# Altium schematic sheet ESP32.SchDoc(ESP32)
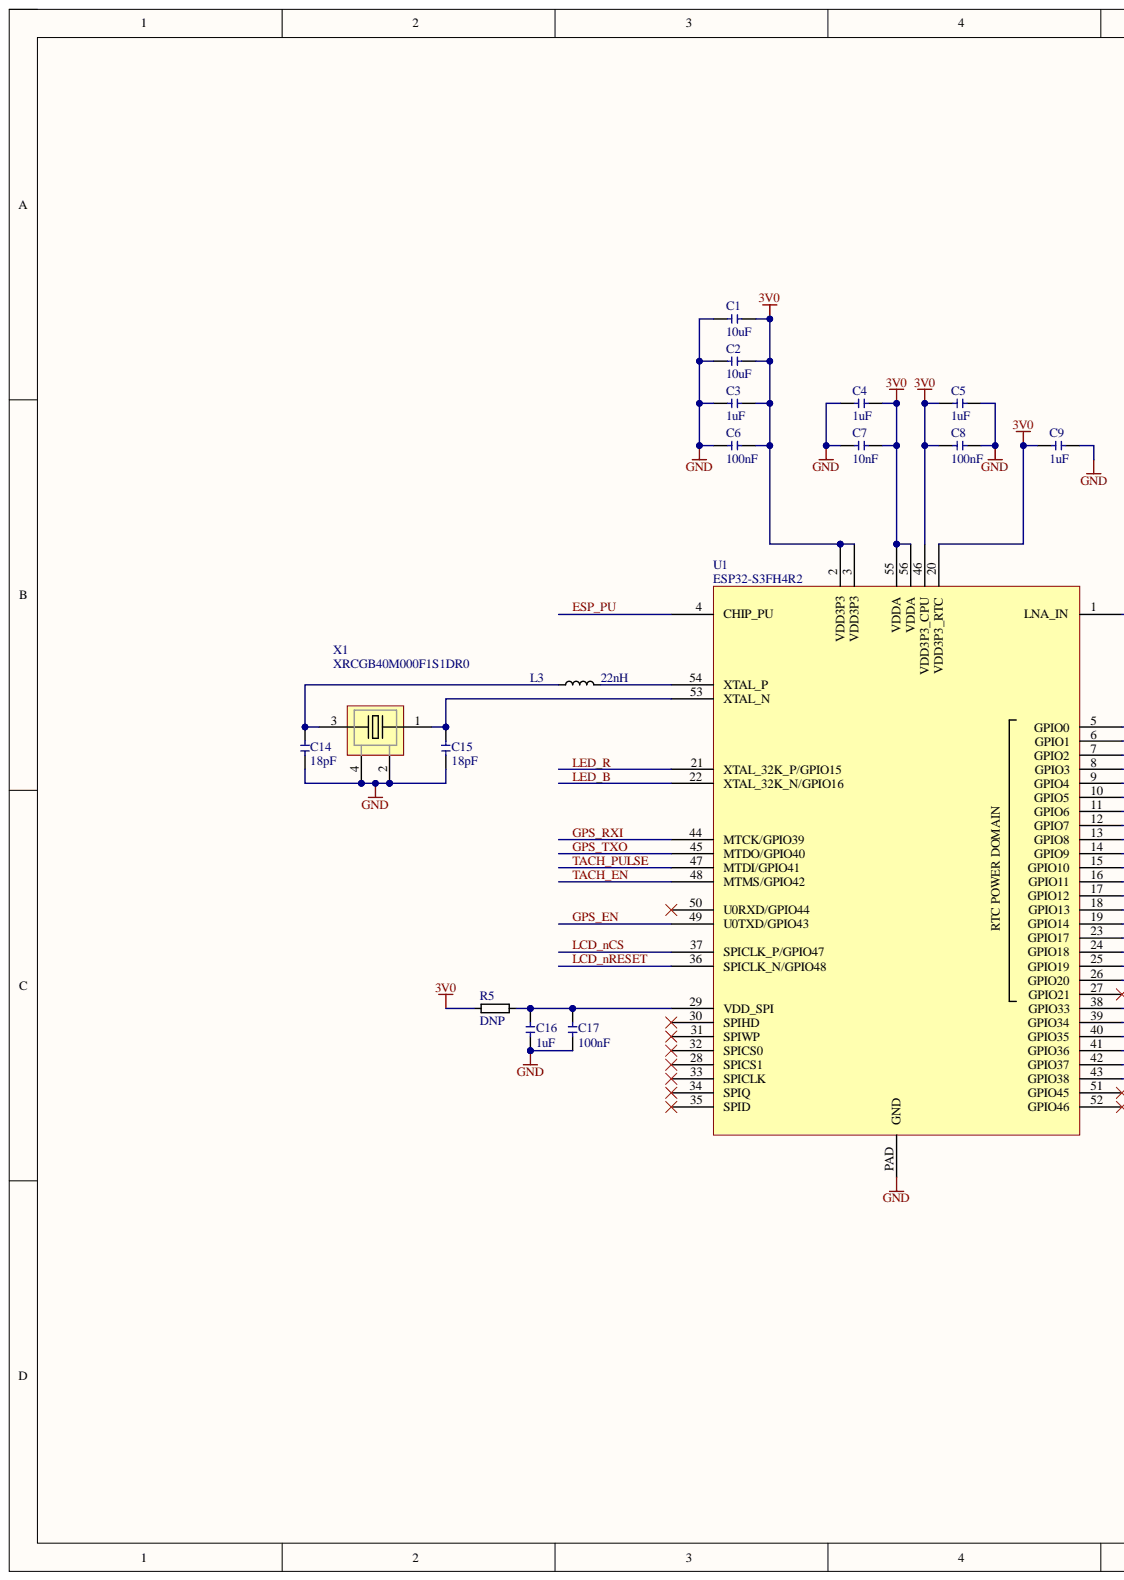
[diagram p0: ESP32.SchDoc, left]
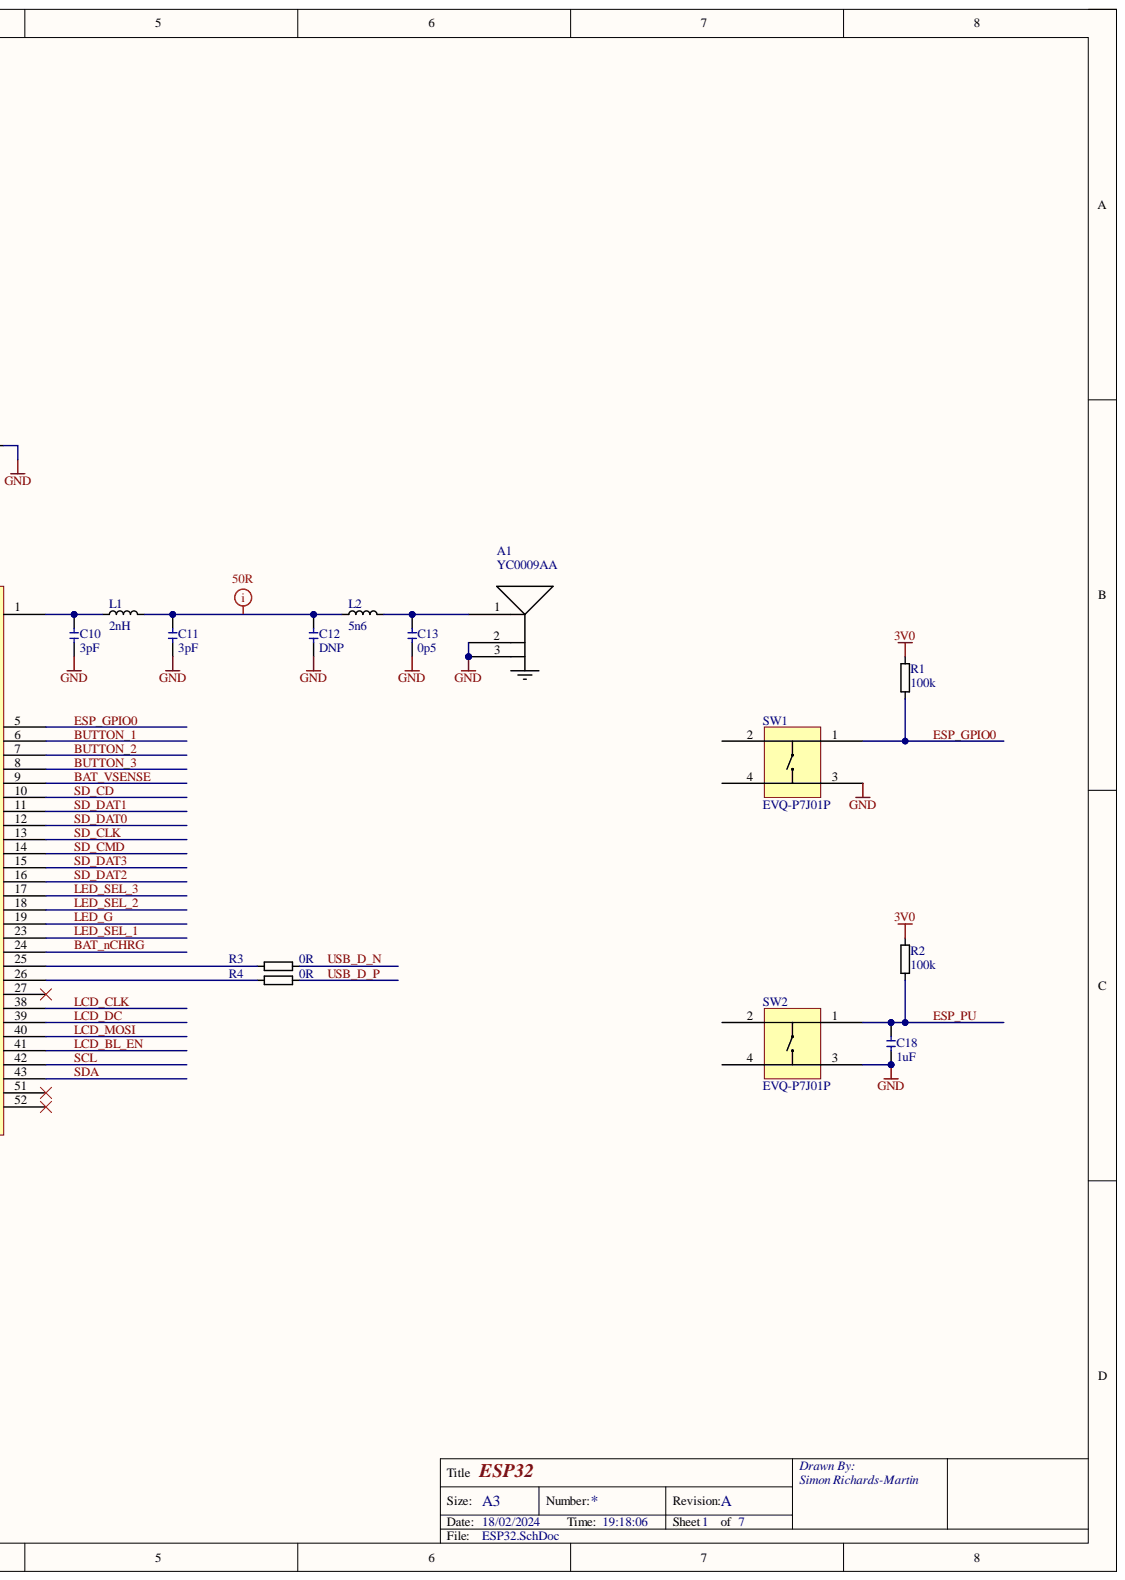
[diagram p1: ESP32.SchDoc, right]

## Parts
A1  YC0009AA  [YC0009AA]
C1  10uF  [CHIP-0402]
C2  10uF  [CHIP-0402]
C3  1uF  [CHIP-0402]
C4  1uF  [CHIP-0402]
C5  1uF  [CHIP-0402]
C6  100nF  [CHIP-0402]
C7  10nF  [CHIP-0402]
C8  100nF  [CHIP-0402]
C9  1uF  [CHIP-0402]
C10  3pF  [CHIP-0402]
C11  3pF  [CHIP-0402]
C12  DNP  [CHIP-0402]
C13  0p5  [CHIP-0402]
C14  18pF  [CHIP-0402]
C15  18pF  [CHIP-0402]
C16  1uF  [CHIP-0402]
C17  100nF  [CHIP-0402]
C18  1uF  [CHIP-0402]
L1  2nH  [CHIP-0402]
L2  5n6  [CHIP-0402]
L3  22nH  [CHIP-0402]
R1  100k  [CHIP-0402]
R2  100k  [CHIP-0402]
R3  0R  [CHIP-0402]
R4  0R  [CHIP-0402]
R5  DNP  [CHIP-0402]
SW1  EVQ-P7J01P  [EVQ-P7J01P]
SW2  EVQ-P7J01P  [EVQ-P7J01P]
U1  ESP32-S3FH4R2  [QFN40P700X700X90-57N]
X1  XRCGB40M000F1S1DR0  [CRYSTAL-1.6X2.0]

## Nets
3V0: C1.2 C2.2 C3.2 C4.2 C5.1 C6.2 C7.2 C8.1 C9.1 R1.2 R2.2 R5.1 U1.2(VDD3P3) U1.3(VDD3P3) U1.20(VDD3P3_RTC) U1.46(VDD3P3_CPU) U1.55(VDDA) U1.56(VDDA)
GND: A1.2 A1.3 C1.1 C2.1 C3.1 C4.1 C5.2 C6.1 C7.1 C8.2 C9.2 C10.2 C11.2 C12.2 C13.2 C14.2 C15.2 C16.2 C17.2 C18.2 SW1.3 SW2.3 U1.PAD(GND) X1.2 X1.4
BAT_nCHRG: U1.24(GPIO18)
BAT_VSENSE: U1.9(GPIO4)
BUTTON_1: U1.6(GPIO1)
BUTTON_2: U1.7(GPIO2)
BUTTON_3: U1.8(GPIO3)
ESP_GPIO0: R1.1 SW1.1 U1.5(GPIO0)
ESP_PU: C18.1 R2.1 SW2.1 U1.4(CHIP_PU)
GPS_EN: U1.49(U0TXD/GPIO43)
GPS_RXI: U1.44(MTCK/GPIO39)
GPS_TXO: U1.45(MTDO/GPIO40)
LCD_BL_EN: U1.41(GPIO36)
LCD_CLK: U1.38(GPIO33)
LCD_DC: U1.39(GPIO34)
LCD_MOSI: U1.40(GPIO35)
LCD_nCS: U1.37(SPICLK_P/GPIO47)
LCD_nRESET: U1.36(SPICLK_N/GPIO48)
LED_B: U1.22(XTAL_32K_N/GPIO16)
LED_G: U1.19(GPIO14)
LED_R: U1.21(XTAL_32K_P/GPIO15)
LED_SEL_1: U1.23(GPIO17)
LED_SEL_2: U1.18(GPIO13)
LED_SEL_3: U1.17(GPIO12)
SCL: U1.42(GPIO37)
SD_CD: U1.10(GPIO5)
SD_CLK: U1.13(GPIO8)
SD_CMD: U1.14(GPIO9)
SD_DAT0: U1.12(GPIO7)
SD_DAT1: U1.11(GPIO6)
SD_DAT2: U1.16(GPIO11)
SD_DAT3: U1.15(GPIO10)
SDA: U1.43(GPIO38)
TACH_EN: U1.48(MTMS/GPIO42)
TACH_PULSE: U1.47(MTDI/GPIO41)
USB_D_N: R3.2
USB_D_P: R4.2
NetA1_1: A1.1 C13.1 L2.1
NetC10_1: C10.1 L1.2 U1.1(LNA_IN)
NetC11_1: C11.1 C12.1 L1.1 L2.2
NetC14_1: C14.1 L3.2 X1.3
NetC15_1: C15.1 U1.53(XTAL_N) X1.1
NetC16_1: C16.1 C17.1 R5.2 U1.29(VDD_SPI)
NetL3_1: L3.1 U1.54(XTAL_P)
NetR3_1: R3.1 U1.25(GPIO19)
NetR4_1: R4.1 U1.26(GPIO20)

Not connected: SW1 2 4; SW2 2 4; U1 27(GPIO21) 28(SPICS1) 30(SPIHD) 31(SPIWP) 32(SPICS0) 33(SPICLK) 34(SPIQ) 35(SPID) 50(U0RXD/GPIO44) 51(GPIO45) 52(GPIO46)

## Library data of the parts
A1 = YC0009AA: Antenna; Manufacturer: Quectel; Component Kind: Standard; MPN: YC0009AA; Library Name: Paramotor Sensor Main.SchLib
C1 C2 = Capacitor 10uF 10V 0402 X5R: Manufacturer: Murata; MPN: GRM155R61A106ME11D; Component Kind: Standard; Description: Capacitor 10uF 10V 0402 X5R; Library Name: Paramotor Sensor Main.SchLib
C3-C5 C9 C16 C18 = Capacitor 1uF 50V 0402 X5R: Manufacturer: Murata; MPN: GRM155R61H105KE05D; Component Kind: Standard; Description: Capacitor 1uF 50V 0402 X5R; Library Name: Paramotor Sensor Main.SchLib
C6 C8 C17 = Capacitor 100nF 50V 0402 X5R: Manufacturer: Murata; MPN: GRM155R61H104KE14D; Component Kind: Standard; Description: Capacitor 100nF 50V 0402 X5R; Library Name: Paramotor Sensor Main.SchLib
C7 = Capacitor 10nF 25V 0402 C0G: Manufacturer: Murata; MPN: GRM1555C1E103GE01D; Component Kind: Standard; Description: Capacitor 10nF 25V 0402 C0G; Library Name: Paramotor Sensor Main.SchLib
C10 C11 = Capacitor RF 3pF 50V 0402 C0G: Manufacturer: Murata; MPN: GJM1555C1H3R0CB01D; Component Kind: Standard; Description: Capacitor RF 3pF 50V 0402 C0G; Library Name: Paramotor Sensor Main.SchLib
C12 = Capacitor DNP 0402: Manufacturer: DO NOT POPULATE; Component Kind: Standard; Description: Capacitor DNP 0402; MPN: DO NOT POPULATE; Library Name: Paramotor Sensor Main.SchLib
C13 = Capacitor RF 0.5pF 50V 0402 C0G: Manufacturer: Murata; MPN: GJM1555C1HR50BB01J; Component Kind: Standard; Description: Capacitor RF 0.5pF 50V 0402 C0G; Library Name: Paramotor Sensor Main.SchLib
C14 C15 = Capacitor 18pF 50V 0402 C0G: Manufacturer: Murata; MPN: GRT1555C1H180JA02D; Component Kind: Standard; Description: Capacitor 18pF 50V 0402 C0G; Library Name: Paramotor Sensor Main.SchLib
L1 = Inductor RF 2nH 90mR 0402: Manufacturer: Murata; MPN: LQG15HN2N0S02D; Component Kind: Standard; Description: Inductor RF 2nH 90mR 0402; Library Name: Paramotor Sensor Main.SchLib
L2 = Inductor RF 5.6nH 180mR 0402: Manufacturer: Murata; MPN: LQG15HN5N6B02D; Component Kind: Standard; Description: Inductor RF 5.6nH 180mR 0402; Library Name: Paramotor Sensor Main.SchLib
L3 = Inductor 22nH 350mA 0402: Manufacturer: Murata; MPN: LQG15HS22NJ02D; Component Kind: Standard; Description: Inductor 22nH 350mA 0402; Library Name: Paramotor Sensor Main.SchLib
R1 R2 = Resistor 100k 1% 0402: Manufacturer: Yageo; MPN: RC0402FR-07100KL; Component Kind: Standard; Description: Resistor 100k 1% 0402; Library Name: Paramotor Sensor Main.SchLib
R3 R4 = Resistor 0R 0402: Manufacturer: Yageo; MPN: RC0402FR-070RL; Component Kind: Standard; Description: Resistor 0R 0402; Library Name: Paramotor Sensor Main.SchLib
R5 = Resistor DNP 0402: Manufacturer: DO NOT POPULATE; Component Kind: Standard; Description: Resistor DNP 0402; MPN: DO NOT POPULATE; Library Name: Paramotor Sensor Main.SchLib
SW1 SW2 = EVQ-P7J01P: Tactile Switch RA SMT; Manufacturer: Panasonic; Component Kind: Standard; MPN: EVQ-P7J01P; Library Name: Paramotor Sensor Main.SchLib
U1 = ESP32-S3FH4R2: MCU Dual-core Integrated 4MB Flash 8MB PSRAM WiFi BT; Manufacturer: Espressif Systems; Component Kind: Standard; MPN: ESP32-S3FH4R2; Library Name: Paramotor Sensor Main.SchLib
X1 = XRCGB40M000F1S1DR0: Crystal 40MHz 12pF 10PPM 2.0x1.6mm; Manufacturer: Murata; Component Kind: Standard; MPN: XRCGB40M000F1S1DR0; Library Name: Paramotor Sensor Main.SchLib
